# Altium schematic sheet CPU.SchDoc
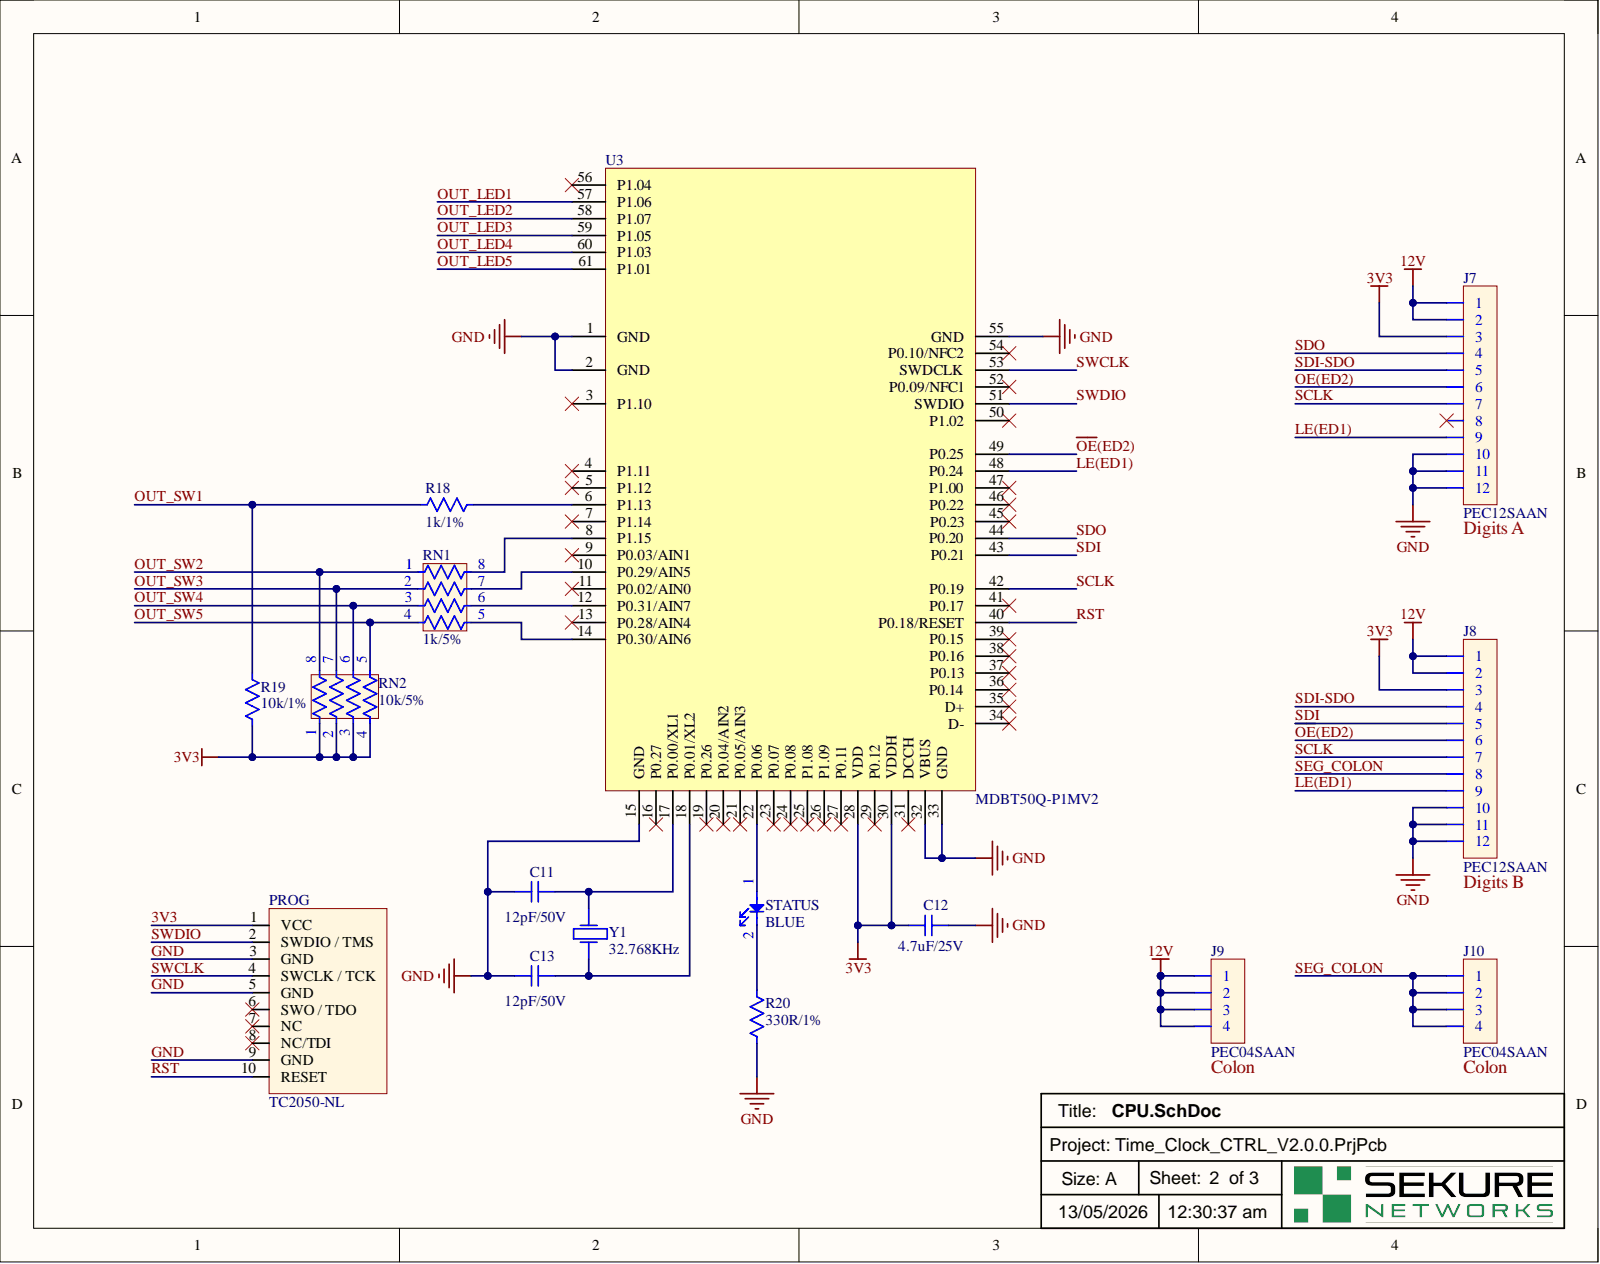
[diagram p0: CPU.SchDoc]

## Parts
C11  value 12pF/50V  [CAP 0603]  (hidden comment: Ceramic Capacitor)
C12  value 4.7uF/25V  [CAP 0603]  (hidden comment: Ceramic Capacitor)
C13  value 12pF/50V  [CAP 0603]  (hidden comment: Ceramic Capacitor)
J7  value PEC12SAAN  [PEC12SAAN]  (hidden comment: Connector)
J8  value PEC12SAAN  [PEC12SAAN]  (hidden comment: Connector)
J9  value PEC04SAAN  [PEC04SAAN]  (hidden comment: Connector)
J10  value PEC04SAAN  [PEC04SAAN]  (hidden comment: Connector)
PROG  value TC2050-NL  [TC2050_full]  (hidden comment: Programming Connector)
R18  value 1k/1%  [RES 0603]  (hidden comment: Resistor)
R19  value 10k/1%  [RES 0603]  (hidden comment: Resistor)
R20  value 330R/1%  [RES 0603]  (hidden comment: Resistor)
RN1  value 1k/5%  [1206 (3216 Metric)]  (hidden comment: Resistor Network)
RN2  value 10k/5%  [1206 (3216 Metric)]  (hidden comment: Resistor Network)
STATUS  value BLUE  [1206 (3216 Metric)]  (hidden comment: LED)
U3  value MDBT50Q-P1MV2  [MDBT50Q-P1MV2]  (hidden comment: BLE Module)
Y1  value 32.768KHz  [ABS07-120-32.768KHZ-T]  (hidden comment: Crystal)

## Nets
12V: J7.1 J7.2 J8.1 J8.2 J9.1 J9.2 J9.3 J9.4
3V3: C12.1 J7.3 J8.3 PROG.1(VCC) R19.2 RN2.1 RN2.2 RN2.3 RN2.4 U3.28(VDD) U3.30(VDDH)
GND: C11.1 C12.2 C13.1 J7.10 J7.11 J7.12 J8.10 J8.11 J8.12 PROG.3(GND) PROG.5(GND) PROG.9(GND) R20.2 U3.1(GND) U3.2(GND) U3.15(GND) U3.32(VBUS) U3.33(GND) U3.55(GND)
LE(ED1): J7.9 J8.9 U3.48(P0.24)
~{OE}(ED2): J7.6 J8.6 U3.49(P0.25)
OUT_LED1: U3.57(P1.06)
OUT_LED2: U3.58(P1.07)
OUT_LED3: U3.59(P1.05)
OUT_LED4: U3.60(P1.03)
OUT_LED5: U3.61(P1.01)
OUT_SW1: R18.2 R19.1
OUT_SW2: RN1.1 RN2.8
OUT_SW3: RN1.2 RN2.7
OUT_SW4: RN1.3 RN2.6
OUT_SW5: RN1.4 RN2.5
RST: PROG.10(RESET) U3.40(P0.18/RESET)
SCLK: J7.7 J8.7 U3.42(P0.19)
SDI: J8.5 U3.43(P0.21)
SDI-SDO: J7.5 J8.4
SDO: J7.4 U3.44(P0.20)
SEG_COLON: J8.8 J10.1 J10.2 J10.3 J10.4
SWCLK: PROG.4(SWCLK_/_TCK) U3.53(SWDCLK)
SWDIO: PROG.2(SWDIO_/_TMS) U3.51(SWDIO)
NetC11_2: C11.2 U3.17(P0.00/XL1) Y1.1
NetC13_2: C13.2 U3.18(P0.01/XL2) Y1.2
NetR18_1: R18.1 U3.6(P1.13)
NetR20_1: R20.1 STATUS.2
NetRN1_5: RN1.5 U3.14(P0.30/AIN6)
NetRN1_6: RN1.6 U3.12(P0.31/AIN7)
NetRN1_7: RN1.7 U3.10(P0.29/AIN5)
NetRN1_8: RN1.8 U3.8(P1.15)
NetSTATUS_1: STATUS.1 U3.22(P0.06)

Not connected: J7 8; PROG 6(SWO_/_TDO) 7(NC) 8(NC/TDI); U3 3(P1.10) 4(P1.11) 5(P1.12) 7(P1.14) 9(P0.03/AIN1) 11(P0.02/AIN0) 13(P0.28/AIN4) 16(P0.27) 19(P0.26) 20(P0.04/AIN2) 21(P0.05/AIN3) 23(P0.07) 24(P0.08) 25(P1.08) 26(P1.09) 27(P0.11) 29(P0.12) 31(DCCH) 34(D-) 35(D+) 36(P0.14) 37(P0.13) 38(P0.16) 39(P0.15) 41(P0.17) 45(P0.23) 46(P0.22) 47(P1.00) 50(P1.02) 52(P0.09/NFC1) 54(P0.10/NFC2) 56(P1.04)

## Library data of the parts
C11 C13 = CL10C120JB81PNC: Cap Ceramic 12pF 50V C0G 5% SMD 0603 125C Automotive Cardboard T/R; Manufacturer: Samsung Electro-Mechanics; Temperature Coefficient: 30ppm/°C; Case Code (Imperial): 0603; Case Code (Metric): 1608; Case/Package: 0603; Category: Ceramic Capacitors; China RoHS: Compliant; Component Kind: Standard; ComponentLink1Description: https://datasheet.octopart.com:443/CL10C120JB81PNC-Samsung-Electro-Mechanics-datasheet-176142735.pdf?src-supplier=IHS; ComponentLink1URL: https://datasheet.octopart.com:443/CL10C120JB81PNC-Samsung-Electro-Mechanics-datasheet-176142735.pdf?src-supplier=IHS; ComponentLink2Description: https://datasheet.octopart.com:443/CL10C120JB81PNC-Samsung-datasheet-26669120.pdf?src-supplier=Future+Electronics; ComponentLink2URL: https://datasheet.octopart.com:443/CL10C120JB81PNC-Samsung-datasheet-26669120.pdf?src-supplier=Future+Electronics; ComponentLink3Description: https://datasheet.octopart.com:443/CL10C120JB81PNC-Samsung-datasheet-12555085.pdf?src-supplier=Future+Electronics; ComponentLink3URL: https://datasheet.octopart.com:443/CL10C120JB81PNC-Samsung-datasheet-12555085.pdf?src-supplier=Future+Electronics; ComponentLink4Description: https://datasheet.octopart.com:443/CL10C120JB81PNC-Samsung-Electro-Mechanics-datasheet-149156513.pdf?src-supplier=Future+Electronics; ComponentLink4URL: https://datasheet.octopart.com:443/CL10C120JB81PNC-Samsung-Electro-Mechanics-datasheet-149156513.pdf?src-supplier=Future+Electronics; ComponentLink5Description: https://datasheet.octopart.com:443/CL10C120JB81PNC-Samsung-datasheet-37081328.pdf?src-supplier=Future+Electronics; ComponentLink5URL: https://datasheet.octopart.com:443/CL10C120JB81PNC-Samsung-datasheet-37081328.pdf?src-supplier=Future+Electronics; ComponentLink6Description: https://datasheet.octopart.com:443/CL10C120JB81PNC-Samsung-datasheet-8326407.pdf?src-supplier=TME; ComponentLink6URL: https://datasheet.octopart.com:443/CL10C120JB81PNC-Samsung-datasheet-8326407.pdf?src-supplier=TME; Depth: 800µm; Dielectric: C0G; Dielectric Material: Ceramic; DigiKey: 1276-2176-1-ND; Height: 800µm; Lead Free: Lead Free; Length: 1.6mm; Library Name: Ceramic Capacitors.IntLib; Lifecycle Status: Production; Material: Ceramic; Max Operating Temperature: 125°C; Min Operating Temperature: -55°C; Mounting Technology: Surface Mount; Number of Terminals: 2; P/N: CL10C120JB81PNC; Pricing: 1=0.1, 10=0.035, 50=0.0234, 100=0.02, 500=0.01432, 1000=0.01258 (USD); Radiation Hardening: No; Ratings: AEC-Q200; REACH SVHC: Yes; RoHS: Compliant; Schedule B: 8532240020, 8532240020|8532240020, 8532240020|8532240020|8532240020, 8532240020|8532240020|8532240020|8532240020; Stock: 18437; Supplier: DigiKey; Thickness: 889µm; Tolerance: 5%; Voltage: 50V; Voltage Rating: 50V; Voltage Rating (DC): 50V; Width: 800µm
C12 = CL10A475MA8NQNC: Ceramic Capacitor, Multilayer, Ceramic, 25V, 20% +Tol, 20% -Tol, X5R, 15% TC, 4.7uF, Surface Mount, 0603; Manufacturer: Samsung; Multilayer: Yes; Capacitor Type: Ceramic; Case/Package: 0603; Category: Ceramic Capacitors; Component Kind: Standard; ComponentLink1Description: https://datasheet.ciiva.com:443/pdfs/VipMasterIC/IC/SEMS/SEMS-S-A0008384515/SEMS-S-A0008873310-1.pdf?src-supplier=IHS+Markit; ComponentLink1URL: https://datasheet.ciiva.com:443/pdfs/VipMasterIC/IC/SEMS/SEMS-S-A0008384515/SEMS-S-A0008873310-1.pdf?src-supplier=IHS+Markit; ComponentLink2Description: https://datasheet.ciiva.com/25787/cl10a475ma8nqnc-25787184.pdf?src-supplier=Digi-Key; ComponentLink2URL: https://datasheet.ciiva.com/25787/cl10a475ma8nqnc-25787184.pdf?src-supplier=Digi-Key; ComponentLink3Description: https://datasheet.ciiva.com/16437/cl10a475ma8nqnc-16437322.pdf?src-supplier=Future+Electronics; ComponentLink3URL: https://datasheet.ciiva.com/16437/cl10a475ma8nqnc-16437322.pdf?src-supplier=Future+Electronics; Dielectric Material: Ceramic; DigiKey: 1276-1903-1-ND; JESD-609 Code: e3; Library Name: Ceramic Capacitors.IntLib; Max Operating Temperature: 85°C; Min Operating Temperature: -55°C; Mounting Technology: Surface Mount; P/N: CL10A475MA8NQNC; Package Shape: Rectangular; Packaging: Tape and Reel; Pins: 2; Pricing: 1=0.27, 10=0.187, 50=0.1244, 100=0.1058, 500=0.07466, 1000=0.06533 (USD); Rated DC Voltage (URdc): 25V; Reach SVHC Compliant: Yes; RoHS: Yes; RoHS Compliant: Yes; Stock: 1889613; Supplier: Digi-Key; Temperature Characteristics Code: X5R; Terminal Finish: Nickel; Termination: Wraparound; Tolerance  (fill in as +-): 20%; Tolerance (fill in as +-): 20%
J7 J8 = PEC12SAAN: Board Connector, 12 Contact(s), 1 Row(s), Male, Straight, 0.1 inch Pitch, Solder Terminal; Manufacturer: Sullins; Connector Type: Male Pin; Current Rating: 3A; Number of Contacts: 12; Pitch: 2.54mm; Plating Thickness: 254nm; Category: Card Edge Connectors; Color: Black; Component Kind: Standard; ComponentLink1Description: https://datasheet.octopart.com:443/PEC12SAAN-Sullins-datasheet-137916713.pdf?src-supplier=ODG+(Origin+Data+Global); ComponentLink1URL: https://datasheet.octopart.com:443/PEC12SAAN-Sullins-datasheet-137916713.pdf?src-supplier=ODG+(Origin+Data+Global); ComponentLink2Description: https://datasheet.octopart.com:443/PEC12SAAN-Sullins-datasheet-41399297.pdf?src-supplier=Future+Electronics; ComponentLink2URL: https://datasheet.octopart.com:443/PEC12SAAN-Sullins-datasheet-41399297.pdf?src-supplier=Future+Electronics; ComponentLink3Description: https://datasheet.octopart.com:443/PEC12SAAN-Sullins-datasheet-21070226.pdf?src-supplier=Future+Electronics; ComponentLink3URL: https://datasheet.octopart.com:443/PEC12SAAN-Sullins-datasheet-21070226.pdf?src-supplier=Future+Electronics; ComponentLink4Description: https://datasheet.octopart.com:443/PEC12SAAN-Sullins-datasheet-8324602.pdf?src-supplier=Future+Electronics; ComponentLink4URL: https://datasheet.octopart.com:443/PEC12SAAN-Sullins-datasheet-8324602.pdf?src-supplier=Future+Electronics; ComponentLink5Description: https://datasheet.octopart.com:443/PEC12SAAN-Sullins-datasheet-118405.pdf?src-supplier=DigiKey; ComponentLink5URL: https://datasheet.octopart.com:443/PEC12SAAN-Sullins-datasheet-118405.pdf?src-supplier=DigiKey; Contact Material: Bronze; Contact Mating Length: 5.842mm; Contact Plating: Tin; DigiKey: S1012E-12-ND; Gender: Male; Height: 2.54mm; Housing Color: Black; Housing Material: Plastic; Lead Free: Lead Free; Length: 30.5mm; Library Name: Connectors.IntLib; Lifecycle Status: Production; Max Operating Temperature: 125°C; Min Operating Temperature: -65°C; Mounting Technology: Through Hole; Number of Positions: 12; Number of Rows: 1; P/N: PEC12SAAN; Packaging: Bulk; Pricing: 1=0.73, 10=0.622, 100=0.5289, 500=0.47202, 1000=0.44948, 5000=0.40123, 10000=0.39216 (USD); REACH SVHC: Yes; RoHS: Compliant; Stock: 2990; Supplier: DigiKey; Terminal Pitch: 2.54mm; Termination: Solder; Width: 2.54mm
J9 J10 = PEC04SAAN: Board Connector, 4 Contact(s), 1 Row(s), Male, Straight, Solder Terminal; Manufacturer: Sullins; Connector Type: Male Pin; Number of Contacts: 4; Pitch: 2.54mm; Category: Card Edge Connectors; Color: Black; Component Kind: Standard; ComponentLink1Description: https://datasheet.octopart.com:443/PEC04SAAN-Sullins-datasheet-137916713.pdf?src-supplier=IHS; ComponentLink1URL: https://datasheet.octopart.com:443/PEC04SAAN-Sullins-datasheet-137916713.pdf?src-supplier=IHS; ComponentLink2Description: https://datasheet.octopart.com:443/PEC04SAAN-Sullins-datasheet-62340791.pdf?src-supplier=Future+Electronics; ComponentLink2URL: https://datasheet.octopart.com:443/PEC04SAAN-Sullins-datasheet-62340791.pdf?src-supplier=Future+Electronics; ComponentLink3Description: https://datasheet.octopart.com:443/PEC04SAAN-Sullins-datasheet-8324602.pdf?src-supplier=Future+Electronics; ComponentLink3URL: https://datasheet.octopart.com:443/PEC04SAAN-Sullins-datasheet-8324602.pdf?src-supplier=Future+Electronics; ComponentLink4Description: https://datasheet.octopart.com:443/PEC04SAAN-Sullins-datasheet-118405.pdf?src-supplier=DigiKey; ComponentLink4URL: https://datasheet.octopart.com:443/PEC04SAAN-Sullins-datasheet-118405.pdf?src-supplier=DigiKey; Contact Material: Bronze; Contact Mating Length: 5.842mm; Contact Plating: Tin; DigiKey: S1012E-04-ND; Export Control Classification Number (ECCN) Code: EAR99; Gender: Male; Harmonized Tariff Schedule (HTS) Code: 8536.69.40.40; Height: 2.54mm; Housing Color: Black; Housing Material: Plastic; Introduction Date: 2012-03-28; Lead Free: Lead Free; Length: 10.2mm; Library Name: Connectors.IntLib; Lifecycle Status: Production; Mounting Technology: Through Hole; Number of Positions: 4; Number of Rows: 1; P/N: PEC04SAAN; Packaging: Bulk; Pricing: 1=0.43, 10=0.363, 100=0.3084, 500=0.27524, 1000=0.26209, 5000=0.23394, 10000=0.22278 (USD); REACH SVHC: Yes; RoHS: Compliant; Stock: 3161; Supplier: DigiKey; Terminal Pitch: 2.54mm; Termination: Solder; Width: 2.54mm
PROG = TC2050_Full: “No Legs” 10-pin Plug-of-Nails™ Cable fitted with a 10-pin 0.1″ pitch ribbon connector.; Assembly: DNP; Component Kind: Standard; Library Name: Programming Connectors.IntLib
R18 = ERJ-U03F1001V: Resistor, thick film, SMD 0603 (1608), 1 kΩ, 0.1 W, ±1 %, ERJU03F1001V; Manufacturer: Panasonic; Manufacturer Lifecycle Status: ACTIVE; Part Series: Anti-Sulfurated Thick Film Chip Resistors; Current Rating: 1A; Power Dissipation: 100mW; Temperature Coefficient: 100ppm/°C; Tolerance: 1%; Voltage Rating: 75V; Case Code (Imperial): 0603; Case Code (Metric): 1608; Case/Package: 0603; Category: Chip SMD Resistors; Component Kind: Standard; ComponentLink1Description: https://datasheet.octopart.com:443/ERJ-U03F1001V-Panasonic-datasheet-85390793.pdf?src-supplier=Panasonic; ComponentLink1URL: https://datasheet.octopart.com:443/ERJ-U03F1001V-Panasonic-datasheet-85390793.pdf?src-supplier=Panasonic; ComponentLink2Description: https://datasheet.octopart.com:443/ERJ-U03F1001V-Panasonic-datasheet-11808117.pdf?src-supplier=RS+(Formerly+Allied+Electronics); ComponentLink2URL: https://datasheet.octopart.com:443/ERJ-U03F1001V-Panasonic-datasheet-11808117.pdf?src-supplier=RS+(Formerly+Allied+Electronics); ComponentLink3Description: https://datasheet.octopart.com:443/ERJ-U03F1001V-Panasonic-datasheet-61627662.pdf?src-supplier=Farnell; ComponentLink3URL: https://datasheet.octopart.com:443/ERJ-U03F1001V-Panasonic-datasheet-61627662.pdf?src-supplier=Farnell; ComponentLink4Description: https://datasheet.octopart.com:443/ERJ-U03F1001V-Panasonic-datasheet-184398019.pdf?src-supplier=Electro+Sonic; ComponentLink4URL: https://datasheet.octopart.com:443/ERJ-U03F1001V-Panasonic-datasheet-184398019.pdf?src-supplier=Electro+Sonic; ComponentLink5Description: https://datasheet.octopart.com:443/ERJ-U03F1001V-Panasonic-datasheet-159616995.pdf?src-supplier=Newark; ComponentLink5URL: https://datasheet.octopart.com:443/ERJ-U03F1001V-Panasonic-datasheet-159616995.pdf?src-supplier=Newark; ComponentLink6Description: https://datasheet.octopart.com:443/ERJ-U03F1001V-Panasonic-datasheet-33006993.pdf?src-supplier=Newark; ComponentLink6URL: https://datasheet.octopart.com:443/ERJ-U03F1001V-Panasonic-datasheet-33006993.pdf?src-supplier=Newark; ComponentLink7Description: https://datasheet.octopart.com:443/ERJU03F1001V-Panasonic-datasheet-164871545.pdf?src-supplier=Burklin+Elektronik; ComponentLink7URL: https://datasheet.octopart.com:443/ERJU03F1001V-Panasonic-datasheet-164871545.pdf?src-supplier=Burklin+Elektronik; ComponentLink8Description: https://datasheet.octopart.com/ERJ-U03F1001V-Panasonic-datasheet-78375410.pdf; ComponentLink8URL: https://datasheet.octopart.com/ERJ-U03F1001V-Panasonic-datasheet-78375410.pdf; Composition: Thick Film; Contact Plating: Tin; Depth: 800µm; DigiKey: 10-ERJ-U03F1001VCT-ND; Height: 450µm; Length: 1.6mm; Library Name: Resistors.IntLib; Lifecycle Status: Production; Max Operating Temperature: 155°C; Min Operating Temperature: -55°C; Mounting Technology: Surface Mount; Number of Terminals: 2; P/N: ERJ-U03F1001V; Packaging: Cut Tape; Power Rating: 100mW; Pricing: 1=0.12, 10=0.057, 25=0.12, 50=0.0372, 100=0.0312, 250=0.02572, 500=0.02248, 1000=0.0199 (EUR); REACH SVHC: Yes; RoHS: Compliant; Stock: 30734; Supplier: DigiKey; Termination: SMD/SMT; Voltage Rating (DC): 75V; Weight: 2.012816mg; Width: 800µm; Working Voltage: 75V
R19 = ERJ-3EKF1002V: Res Thick Film 0603 10K Ohm 1% 1/10W ±100ppm/°C Molded SMD Punched Carrier T/R; Manufacturer: Panasonic; Max Power Dissipation: 100mW; Voltage Rating: 75V; Case Code (Imperial): 0603; Case/Package: 0603; Category: Chip SMD Resistors; China RoHS: Non-Compliant; Component Kind: Standard; ComponentLink1Description: https://datasheet.octopart.com:443/ERJ-3EKF1002V-Panasonic-datasheet-13266541.pdf?src-supplier=Newark; ComponentLink1URL: https://datasheet.octopart.com:443/ERJ-3EKF1002V-Panasonic-datasheet-13266541.pdf?src-supplier=Newark; ComponentLink2Description: https://datasheet.octopart.com:443/ERJ-3EKF1002V-Panasonic-datasheet-5313456.pdf?src-supplier=Newark; ComponentLink2URL: https://datasheet.octopart.com:443/ERJ-3EKF1002V-Panasonic-datasheet-5313456.pdf?src-supplier=Newark; ComponentLink3Description: https://datasheet.octopart.com:443/ERJ-3EKF1002V-Panasonic-datasheet-168681906.pdf?src-supplier=Newark; ComponentLink3URL: https://datasheet.octopart.com:443/ERJ-3EKF1002V-Panasonic-datasheet-168681906.pdf?src-supplier=Newark; ComponentLink4Description: https://datasheet.octopart.com:443/ERJ-3EKF1002V-Panasonic-datasheet-48891056.pdf?src-supplier=Farnell; ComponentLink4URL: https://datasheet.octopart.com:443/ERJ-3EKF1002V-Panasonic-datasheet-48891056.pdf?src-supplier=Farnell; ComponentLink5Description: https://datasheet.octopart.com:443/ERJ-3EKF1002V-Panasonic-datasheet-136608610.pdf?src-supplier=Farnell; ComponentLink5URL: https://datasheet.octopart.com:443/ERJ-3EKF1002V-Panasonic-datasheet-136608610.pdf?src-supplier=Farnell; ComponentLink6Description: https://datasheet.octopart.com:443/ERJ-3EKF1002V-Panasonic-datasheet-10025800.pdf?src-supplier=element14+APAC; ComponentLink6URL: https://datasheet.octopart.com:443/ERJ-3EKF1002V-Panasonic-datasheet-10025800.pdf?src-supplier=element14+APAC; ComponentLink7Description: https://datasheet.octopart.com:443/ERJ-3EKF1002V-Panasonic-datasheet-8853621.pdf?src-supplier=element14+APAC; ComponentLink7URL: https://datasheet.octopart.com:443/ERJ-3EKF1002V-Panasonic-datasheet-8853621.pdf?src-supplier=element14+APAC; ComponentLink8Description: https://datasheet.octopart.com:443/ERJ-3EKF1002V-Panasonic-datasheet-82288794.pdf?src-supplier=Future+Electronics; ComponentLink8URL: https://datasheet.octopart.com:443/ERJ-3EKF1002V-Panasonic-datasheet-82288794.pdf?src-supplier=Future+Electronics; ComponentLink9Description: https://datasheet.octopart.com:443/ERJ-3EKF1002V-Panasonic-datasheet-154766169.pdf?src-supplier=Master+Electronics; ComponentLink9URL: https://datasheet.octopart.com:443/ERJ-3EKF1002V-Panasonic-datasheet-154766169.pdf?src-supplier=Master+Electronics; ComponentLink10Description: https://datasheet.octopart.com:443/ERJ3EKF1002V-Panasonic-datasheet-138819429.pdf?src-supplier=Burklin+Elektronik; ComponentLink10URL: https://datasheet.octopart.com:443/ERJ3EKF1002V-Panasonic-datasheet-138819429.pdf?src-supplier=Burklin+Elektronik; ComponentLink11Description: https://datasheet.octopart.com/ERJ-3EKF1002V-Panasonic-datasheet-78375410.pdf; ComponentLink11URL: https://datasheet.octopart.com/ERJ-3EKF1002V-Panasonic-datasheet-78375410.pdf; ComponentLink12Description: https://datasheet.octopart.com/Precision-Thick-Film-Chip-Resistors-Panasonic-datasheet-family-106.pdf; ComponentLink12URL: https://datasheet.octopart.com/Precision-Thick-Film-Chip-Resistors-Panasonic-datasheet-family-106.pdf; ComponentLink13Description: https://datasheet.octopart.com:443/ERJ-3EKF1002V-Panasonic-datasheet-11542829.pdf?src-supplier=Arrow+Electronics; ComponentLink13URL: https://datasheet.octopart.com:443/ERJ-3EKF1002V-Panasonic-datasheet-11542829.pdf?src-supplier=Arrow+Electronics; ComponentLink14Description: https://datasheet.octopart.com:443/ERJ-3EKF1002V-Panasonic-datasheet-7538305.pdf?src-supplier=Jameco; ComponentLink14URL: https://datasheet.octopart.com:443/ERJ-3EKF1002V-Panasonic-datasheet-7538305.pdf?src-supplier=Jameco; ComponentLink15Description: https://datasheet.octopart.com:443/ERJ-3EKF1002V-Panasonic-datasheet-118621.pdf?src-supplier=DigiKey; ComponentLink15URL: https://datasheet.octopart.com:443/ERJ-3EKF1002V-Panasonic-datasheet-118621.pdf?src-supplier=DigiKey; ComponentLink16Description: https://datasheet.octopart.com:443/ERJ-3EKF1002V-Panasonic-datasheet-41391857.pdf?src-supplier=Electro+Sonic; ComponentLink16URL: https://datasheet.octopart.com:443/ERJ-3EKF1002V-Panasonic-datasheet-41391857.pdf?src-supplier=Electro+Sonic; Composition: Thick Film; Contact Plating: Tin; Depth: 800µm; DigiKey: P10.0KHCT-ND; Features: Automotive AEC-Q200; Height: 450µm; Length: 1.6mm; Library Name: Resistors.IntLib; Lifecycle Status: Production; Max Operating Temperature: 155°C; Military Standard: Not; Min Operating Temperature: -55°C; Mounting Technology: Surface Mount; Number of Terminals: 2; Number of Terminations: 2; P/N: ERJ-3EKF1002V; Packaging: Cut Tape; Pins: 2; Power Dissipation: 100mW; Power Rating: 100mW; Pricing: 1=0.1, 10=0.034, 25=0.0256, 50=0.021, 100=0.0173, 250=0.01364, 500=0.01158, 1000=0.01021 (USD); Radiation Hardening: No; REACH SVHC: Yes; RoHS: Compliant; Schedule B: 8533210045, 8533210045|8533210045|8533210045|8533210045|8533210045|8533210045|8533210045|8533210045|8533210045|8533210045|8533210045|8533210045|8533210045|8533210045|8533210045|8533210045|8533210045|8533210045|8533210045|8533210045|8533210045|8533210045|8533210045|85; Stock: 1304666; Supplier: DigiKey; Temperature Coefficient: 100ppm/°C; Termination: SMD/SMT; Tolerance: 1%; Voltage Rating (DC): 75V; Width: 800µm; Working Voltage: 75V
R20 = ERJ3EKF3300V: RES SMD 330 OHM 1% 1/10W 0603; Manufacturer: Panasonic; Max Power Dissipation: 100mW; Resistance: 330R; Temperature Coefficient: 100ppm/°C; Voltage Rating: 75V; Case Code (Imperial): 0603; Case Code (Metric): 1608; Case/Package: 0603; Component Kind: Standard; ComponentLink1Description: https://datasheet.ciiva.com/48531/aoa0000ce2-48531001.pdf?src-supplier=Avnet; ComponentLink1URL: https://datasheet.ciiva.com/48531/aoa0000ce2-48531001.pdf?src-supplier=Avnet; ComponentLink2Description: https://datasheet.ciiva.com/22721/426256-22721099.pdf?src-supplier=Element14; ComponentLink2URL: https://datasheet.ciiva.com/22721/426256-22721099.pdf?src-supplier=Element14; ComponentLink3Description: https://datasheet.ciiva.com/46373/1678782-46373899.pdf?src-supplier=Element14; ComponentLink3URL: https://datasheet.ciiva.com/46373/1678782-46373899.pdf?src-supplier=Element14; ComponentLink4Description: https://datasheet.ciiva.com/7886/aoa0000ce2-7886729.pdf?src-supplier=Element14; ComponentLink4URL: https://datasheet.ciiva.com/7886/aoa0000ce2-7886729.pdf?src-supplier=Element14; ComponentLink5Description: https://datasheet.ciiva.com/9117/aoa0000ce2-9117132.pdf?src-supplier=Avnet; ComponentLink5URL: https://datasheet.ciiva.com/9117/aoa0000ce2-9117132.pdf?src-supplier=Avnet; ComponentLink6Description: https://datasheet.ciiva.com/46373/1678782-46373900.pdf?src-supplier=Element14; ComponentLink6URL: https://datasheet.ciiva.com/46373/1678782-46373900.pdf?src-supplier=Element14; Composition: Thick Film; Contact Plating: Tin; Depth: 0.8mm; DigiKey: P330HCT-ND; Features: Automotive AEC-Q200; Height: 0.45mm; Lead Free: Lead Free; Length: 1.6mm; Library Name: Resistors.IntLib; Max Operating Temperature: 155°C; Military Standard: Not; Min Operating Temperature: -55°C; Mount: Surface Mount; Number of Pins: 2; Number of Terminations: 2; P/N: ERJ3EKF3300V; Package Quantity: 5000; Packaging: Tape and Reel; Power Rating: 100mW; Pricing 1: 1=0.10000, 10=0.07000, 100=0.02840, 1000=0.01275, 2500=0.01106 (USD); Radiation Hardening: No; REACH SVHC: No SVHC; RoHS: Yes; Stock 1: 1950276; Supplier 1: Digi-Key; Termination: SMD/SMT; Tolerance: 1%; Voltage Rating (DC): 75V; Weight: 7.1E-05oz; Width: 0.8mm
RN1 = EXB-38V102JV: Res Thick Film Array 1K Ohm 5% ±200ppm/°C ISOL 8-Pin 1206; Manufacturer: Panasonic; Circuit Type: Isolated; Number of Circuits: 4; Number of Contacts: 8; Number of Elements: 1; Power Dissipation: 63mW; Power Per Element: 62.5mW; Power Rating: 252mW; Voltage Rating: 50V; Case Code (Imperial): 1206; Case Code (Metric): 3216; Category: Resistor Arrays; Component Kind: Standard; ComponentLink1Description: https://datasheet.octopart.com:443/EXB-38V102JV-Panasonic-datasheet-41578787.pdf?src-supplier=Future+Electronics; ComponentLink1URL: https://datasheet.octopart.com:443/EXB-38V102JV-Panasonic-datasheet-41578787.pdf?src-supplier=Future+Electronics; ComponentLink2Description: https://datasheet.octopart.com:443/EXB-38V102JV-Panasonic-datasheet-13266625.pdf?src-supplier=RS+(Formerly+Allied+Electronics); ComponentLink2URL: https://datasheet.octopart.com:443/EXB-38V102JV-Panasonic-datasheet-13266625.pdf?src-supplier=RS+(Formerly+Allied+Electronics); ComponentLink3Description: https://datasheet.octopart.com:443/EXB-38V102JV-Panasonic-datasheet-136608612.pdf?src-supplier=Farnell; ComponentLink3URL: https://datasheet.octopart.com:443/EXB-38V102JV-Panasonic-datasheet-136608612.pdf?src-supplier=Farnell; ComponentLink4Description: https://datasheet.octopart.com:443/EXB-38V102JV-Panasonic---ECG-datasheet-15994758.pdf?src-supplier=Farnell; ComponentLink4URL: https://datasheet.octopart.com:443/EXB-38V102JV-Panasonic---ECG-datasheet-15994758.pdf?src-supplier=Farnell; ComponentLink5Description: https://datasheet.octopart.com:443/EXB-38V102JV-Panasonic-datasheet-10404292.pdf?src-supplier=Newark; ComponentLink5URL: https://datasheet.octopart.com:443/EXB-38V102JV-Panasonic-datasheet-10404292.pdf?src-supplier=Newark; ComponentLink6Description: https://datasheet.octopart.com:443/EXB-38V102JV-Panasonic-datasheet-22087674.pdf?src-supplier=Newark; ComponentLink6URL: https://datasheet.octopart.com:443/EXB-38V102JV-Panasonic-datasheet-22087674.pdf?src-supplier=Newark; ComponentLink7Description: https://datasheet.octopart.com:443/EXB-38V102JV-Panasonic-datasheet-154766207.pdf?src-supplier=Master+Electronics; ComponentLink7URL: https://datasheet.octopart.com:443/EXB-38V102JV-Panasonic-datasheet-154766207.pdf?src-supplier=Master+Electronics; ComponentLink8Description: https://datasheet.octopart.com:443/EXB-38V102JV-Panasonic-datasheet-38538506.pdf?src-supplier=Electro+Sonic; ComponentLink8URL: https://datasheet.octopart.com:443/EXB-38V102JV-Panasonic-datasheet-38538506.pdf?src-supplier=Electro+Sonic; ComponentLink9Description: https://datasheet.octopart.com:443/EXB38V102JV-Panasonic-datasheet-27908906.pdf?src-supplier=Electro+Sonic; ComponentLink9URL: https://datasheet.octopart.com:443/EXB38V102JV-Panasonic-datasheet-27908906.pdf?src-supplier=Electro+Sonic; ComponentLink10Description: https://datasheet.octopart.com:443/EXB38V102JV-Panasonic-datasheet-188913051.pdf?src-supplier=TME; ComponentLink10URL: https://datasheet.octopart.com:443/EXB38V102JV-Panasonic-datasheet-188913051.pdf?src-supplier=TME; ComponentLink11Description: https://datasheet.octopart.com/EXB-38V102JV-Panasonic-datasheet-78375410.pdf; ComponentLink11URL: https://datasheet.octopart.com/EXB-38V102JV-Panasonic-datasheet-78375410.pdf; ComponentLink12Description: https://datasheet.octopart.com/Thick-Film-Chip-Resistor-Networks-%7C-EXB-Panasonic-datasheet-family-112.pdf; ComponentLink12URL: https://datasheet.octopart.com/Thick-Film-Chip-Resistor-Networks-%7C-EXB-Panasonic-datasheet-family-112.pdf; ComponentLink13Description: https://datasheet.octopart.com:443/EXB-38V102JV-Panasonic-datasheet-11546899.pdf?src-supplier=Arrow+Electronics; ComponentLink13URL: https://datasheet.octopart.com:443/EXB-38V102JV-Panasonic-datasheet-11546899.pdf?src-supplier=Arrow+Electronics; ComponentLink14Description: https://datasheet.octopart.com:443/EXB-38V102JV-Panasonic-datasheet-7279555.pdf?src-supplier=Jameco; ComponentLink14URL: https://datasheet.octopart.com:443/EXB-38V102JV-Panasonic-datasheet-7279555.pdf?src-supplier=Jameco; ComponentLink15Description: https://datasheet.octopart.com:443/EXB-38V102JV-Panasonic-datasheet-118644.pdf?src-supplier=DigiKey; ComponentLink15URL: https://datasheet.octopart.com:443/EXB-38V102JV-Panasonic-datasheet-118644.pdf?src-supplier=DigiKey; Contact Plating: Tin; Depth: 1.6mm; DigiKey: Y9102CT-ND; Height: 500µm; Lead Free: Lead Free; Length: 3.2mm; Library Name: Resistors Array.IntLib; Lifecycle Status: Production; Max Operating Temperature: 125°C; Military Standard: Not; Min Operating Temperature: -55°C; Mounting Technology: Surface Mount; Number of Resistors: 4; Number of Terminals: 8; P/N: EXB-38V102JV; Packaging: Cut Tape; Pins: 8; Pricing: 1=0.1, 10=0.051, 25=0.0428, 50=0.0376, 100=0.0331, 250=0.02816, 500=0.02504, 1000=0.02239 (USD); Radiation Hardening: No; REACH SVHC: Yes; RoHS: Compliant; Stock: 51454; Supplier: DigiKey; Temperature Coefficient: 200ppm/°C; Terminal Pitch: 800µm; Termination: Convex; Tolerance: 5%; Voltage Rating (DC): 50V; Width: 1.6mm; Working Voltage: 50V
RN2 = EXB-38V103JV: Res Thick Film Array 1206 10K Ohm 5% ±200ppm/°C ISOL 8-Pin Convex SMD Punched Carrier T/R; Manufacturer: Panasonic; Circuit Type: Isolated; Number of Circuits: 4; Number of Contacts: 8; Number of Elements: 1; Power Dissipation: 63mW; Power Per Element: 62.5mW; Voltage Rating: 50V; Case Code (Imperial): 1206; Case Code (Metric): 3216; Case/Package: 1206; Category: Resistor Arrays; Component Kind: Standard; ComponentLink1Description: https://datasheet.octopart.com:443/EXB-38V103JV-Panasonic-datasheet-138897389.pdf?src-supplier=Upverter; ComponentLink1URL: https://datasheet.octopart.com:443/EXB-38V103JV-Panasonic-datasheet-138897389.pdf?src-supplier=Upverter; ComponentLink2Description: https://sigma.octopart.com:443/138766958/technical_drawing/Panasonic-EXB-38V103JV.pdf?src-supplier=Upverter; ComponentLink2URL: https://sigma.octopart.com:443/138766958/technical_drawing/Panasonic-EXB-38V103JV.pdf?src-supplier=Upverter; ComponentLink3Description: https://datasheet.octopart.com:443/EXB-38V103JV-Panasonic-datasheet-13266625.pdf?src-supplier=RS+(Formerly+Allied+Electronics); ComponentLink3URL: https://datasheet.octopart.com:443/EXB-38V103JV-Panasonic-datasheet-13266625.pdf?src-supplier=RS+(Formerly+Allied+Electronics); ComponentLink4Description: https://datasheet.octopart.com:443/EXB-38V103JV-Panasonic-datasheet-41578787.pdf?src-supplier=Panasonic+SCT; ComponentLink4URL: https://datasheet.octopart.com:443/EXB-38V103JV-Panasonic-datasheet-41578787.pdf?src-supplier=Panasonic+SCT; ComponentLink5Description: https://datasheet.octopart.com:443/EXB-38V103JV-Panasonic-datasheet-136608612.pdf?src-supplier=Newark; ComponentLink5URL: https://datasheet.octopart.com:443/EXB-38V103JV-Panasonic-datasheet-136608612.pdf?src-supplier=Newark; ComponentLink6Description: https://datasheet.octopart.com:443/EXB-38V103JV-Panasonic-datasheet-10404292.pdf?src-supplier=Newark; ComponentLink6URL: https://datasheet.octopart.com:443/EXB-38V103JV-Panasonic-datasheet-10404292.pdf?src-supplier=Newark; ComponentLink7Description: https://datasheet.octopart.com:443/EXB-38V103JV-Panasonic-datasheet-22087674.pdf?src-supplier=Newark; ComponentLink7URL: https://datasheet.octopart.com:443/EXB-38V103JV-Panasonic-datasheet-22087674.pdf?src-supplier=Newark; ComponentLink8Description: https://datasheet.octopart.com:443/EXB-38V103JV-Panasonic-datasheet-154766207.pdf?src-supplier=Master+Electronics; ComponentLink8URL: https://datasheet.octopart.com:443/EXB-38V103JV-Panasonic-datasheet-154766207.pdf?src-supplier=Master+Electronics; ComponentLink9Description: https://datasheet.octopart.com:443/EXB-38V103JV-Panasonic-datasheet-38538506.pdf?src-supplier=Electro+Sonic; ComponentLink9URL: https://datasheet.octopart.com:443/EXB-38V103JV-Panasonic-datasheet-38538506.pdf?src-supplier=Electro+Sonic; ComponentLink10Description: https://datasheet.octopart.com:443/EXB38V103JV-Panasonic-datasheet-184959162.pdf?src-supplier=Electro+Sonic; ComponentLink10URL: https://datasheet.octopart.com:443/EXB38V103JV-Panasonic-datasheet-184959162.pdf?src-supplier=Electro+Sonic; ComponentLink11Description: https://datasheet.octopart.com:443/EXB38V103JV-Panasonic-datasheet-27908906.pdf?src-supplier=Electro+Sonic; ComponentLink11URL: https://datasheet.octopart.com:443/EXB38V103JV-Panasonic-datasheet-27908906.pdf?src-supplier=Electro+Sonic; ComponentLink12Description: https://datasheet.octopart.com:443/EXB38V103JV-Panasonic-datasheet-188913051.pdf?src-supplier=TME; ComponentLink12URL: https://datasheet.octopart.com:443/EXB38V103JV-Panasonic-datasheet-188913051.pdf?src-supplier=TME; ComponentLink13Description: https://datasheet.octopart.com/EXB-38V103JV-Panasonic-datasheet-78375410.pdf; ComponentLink13URL: https://datasheet.octopart.com/EXB-38V103JV-Panasonic-datasheet-78375410.pdf; ComponentLink14Description: https://datasheet.octopart.com:443/EXB-38V103JV-Panasonic---ECG-datasheet-15994758.pdf?src-supplier=Farnell; ComponentLink14URL: https://datasheet.octopart.com:443/EXB-38V103JV-Panasonic---ECG-datasheet-15994758.pdf?src-supplier=Farnell; ComponentLink15Description: https://datasheet.octopart.com:443/EXB-38V103JV-Panasonic-datasheet-11546899.pdf?src-supplier=Arrow+Electronics; ComponentLink15URL: https://datasheet.octopart.com:443/EXB-38V103JV-Panasonic-datasheet-11546899.pdf?src-supplier=Arrow+Electronics; ComponentLink16Description: https://datasheet.octopart.com:443/EXB-38V103JV-Panasonic-datasheet-7277236.pdf?src-supplier=Jameco; ComponentLink16URL: https://datasheet.octopart.com:443/EXB-38V103JV-Panasonic-datasheet-7277236.pdf?src-supplier=Jameco; ComponentLink17Description: https://datasheet.octopart.com:443/EXB-38V103JV-Panasonic-datasheet-118644.pdf?src-supplier=DigiKey; ComponentLink17URL: https://datasheet.octopart.com:443/EXB-38V103JV-Panasonic-datasheet-118644.pdf?src-supplier=DigiKey; Composition: Thick Film; Contact Plating: Tin; Depth: 1.6mm; DigiKey: Y9103CT-ND; Height: 500µm; Lead Free: Lead Free; Length: 3.2mm; Library Name: Resistors Array.IntLib; Lifecycle Status: Production; Max Operating Temperature: 125°C; Military Standard: Not; Min Operating Temperature: -55°C; Mounting Technology: Surface Mount; Number of Resistors: 4; Number of Terminals: 8; P/N: EXB-38V103JV; Packaging: Cut Tape; Pins: 8; Pricing: 1=0.1, 10=0.051, 25=0.0428, 50=0.0376, 100=0.0331, 250=0.02816, 500=0.02504, 1000=0.02239 (USD); Radiation Hardening: No; REACH SVHC: Yes; RoHS: Compliant; Stock: 234882; Supplier: DigiKey; Temperature Coefficient: 200ppm/°C; Terminal Pitch: 800µm; Termination: Convex; Tolerance: 5%; Width: 1.6mm; Working Voltage: 50V
STATUS = LTST-C150TBKT: Blue 3.2 x 1.6 x 1.1 mm 130° Water Clear 70 mcd 2 V LED Surface Mount; Manufacturer: Vishay Lite-On; Category: LEDs; Component Kind: Standard; ComponentLink1Description: https://datasheet.ciiva.com/15117/getdatasheetpartid-141647-15117734.pdf?src-supplier=Verical; ComponentLink1URL: https://datasheet.ciiva.com/15117/getdatasheetpartid-141647-15117734.pdf?src-supplier=Verical; ComponentLink2Description: https://datasheet.ciiva.com/34303/1996102-34303714.pdf?src-supplier=Jameco; ComponentLink2URL: https://datasheet.ciiva.com/34303/1996102-34303714.pdf?src-supplier=Jameco; ComponentLink3Description: https://datasheet.ciiva.com/11774/0900766b80dc0268-11774376.pdf?src-supplier=Rs; ComponentLink3URL: https://datasheet.ciiva.com/11774/0900766b80dc0268-11774376.pdf?src-supplier=Rs; ComponentLink4Description: https://datasheet.ciiva.com/12400/lite-on-ltst-c150tbkt-187416-12400802.pdf?src-supplier=Mouser; ComponentLink4URL: https://datasheet.ciiva.com/12400/lite-on-ltst-c150tbkt-187416-12400802.pdf?src-supplier=Mouser; ComponentLink5Description: https://datasheet.ciiva.com/16388/ltst-c150tbkt-16388566.pdf?src-supplier=Future+Electronics; ComponentLink5URL: https://datasheet.ciiva.com/16388/ltst-c150tbkt-16388566.pdf?src-supplier=Future+Electronics; ComponentLink6Description: https://datasheet.ciiva.com/2110/s-110-ltst-c150tbkt0630-2110485.pdf?src-supplier=Digikey; ComponentLink6URL: https://datasheet.ciiva.com/2110/s-110-ltst-c150tbkt0630-2110485.pdf?src-supplier=Digikey; ComponentLink7Description: https://datasheet.ciiva.com/2110/s-110-ltst-c150tbkt0630-2110486.pdf?src-supplier=Digikey; ComponentLink7URL: https://datasheet.ciiva.com/2110/s-110-ltst-c150tbkt0630-2110486.pdf?src-supplier=Digikey; ComponentLink8Description: https://datasheet.ciiva.com/2110/s-110-ltst-c150tbkt0630-2110487.pdf?src-supplier=Digikey; ComponentLink8URL: https://datasheet.ciiva.com/2110/s-110-ltst-c150tbkt0630-2110487.pdf?src-supplier=Digikey; DigiKey: 160-1643-1-ND; Library Name: LEDs.IntLib; P/N: LTST-C150TBKT; Pricing: 1=0.35, 10=0.2, 100=0.124, 500=0.09432, 1000=0.08525 (USD); RoHS: Yes; Stock: 118195; Supplier: Digikey
U3 = MDBT50Q-P1MV2: 802.15.4, Bluetooth Bluetooth v5.4, Zigbee® Transceiver Module 2.36GHz ~ 2.5GHz PCB Trace Surface Mount; Manufacturer: Raytac; Component Kind: Standard; DigiKey: 3271-MDBT50Q-P1MV2-ND; Library Name: Wireless_Modules.IntLib; P/N: MDBT50Q-P1MV2
Y1 = FC-13532.7680KA-AC: Crystal 0.032768MHz ±20ppm (Tol) 9pF FUND 70000Ohm 2-Pin CSMD Bulk; Manufacturer: Epson; Drive Level: 0.5uW; ESR (Equivalent Series Resistance): 70kR; Case/Package: SMD/SMT; Category: Crystals; Component Kind: Standard; ComponentLink1Description: http://www.mouser.com/ds/2/137/1699449-465441.pdf?src-supplier=Mouser; ComponentLink1URL: http://www.mouser.com/ds/2/137/1699449-465441.pdf?src-supplier=Mouser; ComponentLink2Description: https://support.epson.biz/td/api/doc_check.php?dl=brief_FC-135&lang=en&src-supplier=Digikey; ComponentLink2URL: https://support.epson.biz/td/api/doc_check.php?dl=brief_FC-135&lang=en&src-supplier=Digikey; ComponentLink3Description: https://support.epson.biz/td/api/doc_check.php?dl=brief_FC-135R_en.pdf&src-supplier=Digikey; ComponentLink3URL: https://support.epson.biz/td/api/doc_check.php?dl=brief_FC-135R_en.pdf&src-supplier=Digikey; DigiKey: FC-13532.7680KA-AC-ND; Frequency: 32.768kHz; Frequency Tolerance: 20ppm; Height: 0.035inch; Length: 0.126inch; Library Name: Crystals.IntLib; Load Capacitance: 9pF; Max Operating Temperature: 85°C; Min Operating Temperature: -40°C; Mounting Technology: Surface Mount; P/N: FC-13532.7680KA-AC; Package Quantity: 1; Packaging: Bulk; Pricing: 1=2.66, 10=2.31, 25=2.184, 50=2.0938, 100=2.0072, 250=1.89824, 500=1.81982, 1000=1.7447, 2500=1.65025 (USD); RoHS: No; RoHS Compliant: No; Series: FC-135; Stock: 820; Supplier: Digikey; Termination: SMD/SMT; Tolerance: 20ppm; Weight: 0.000564oz; Width: 1.5mm
